# Altium schematic sheet 1166V30-4.SchDoc
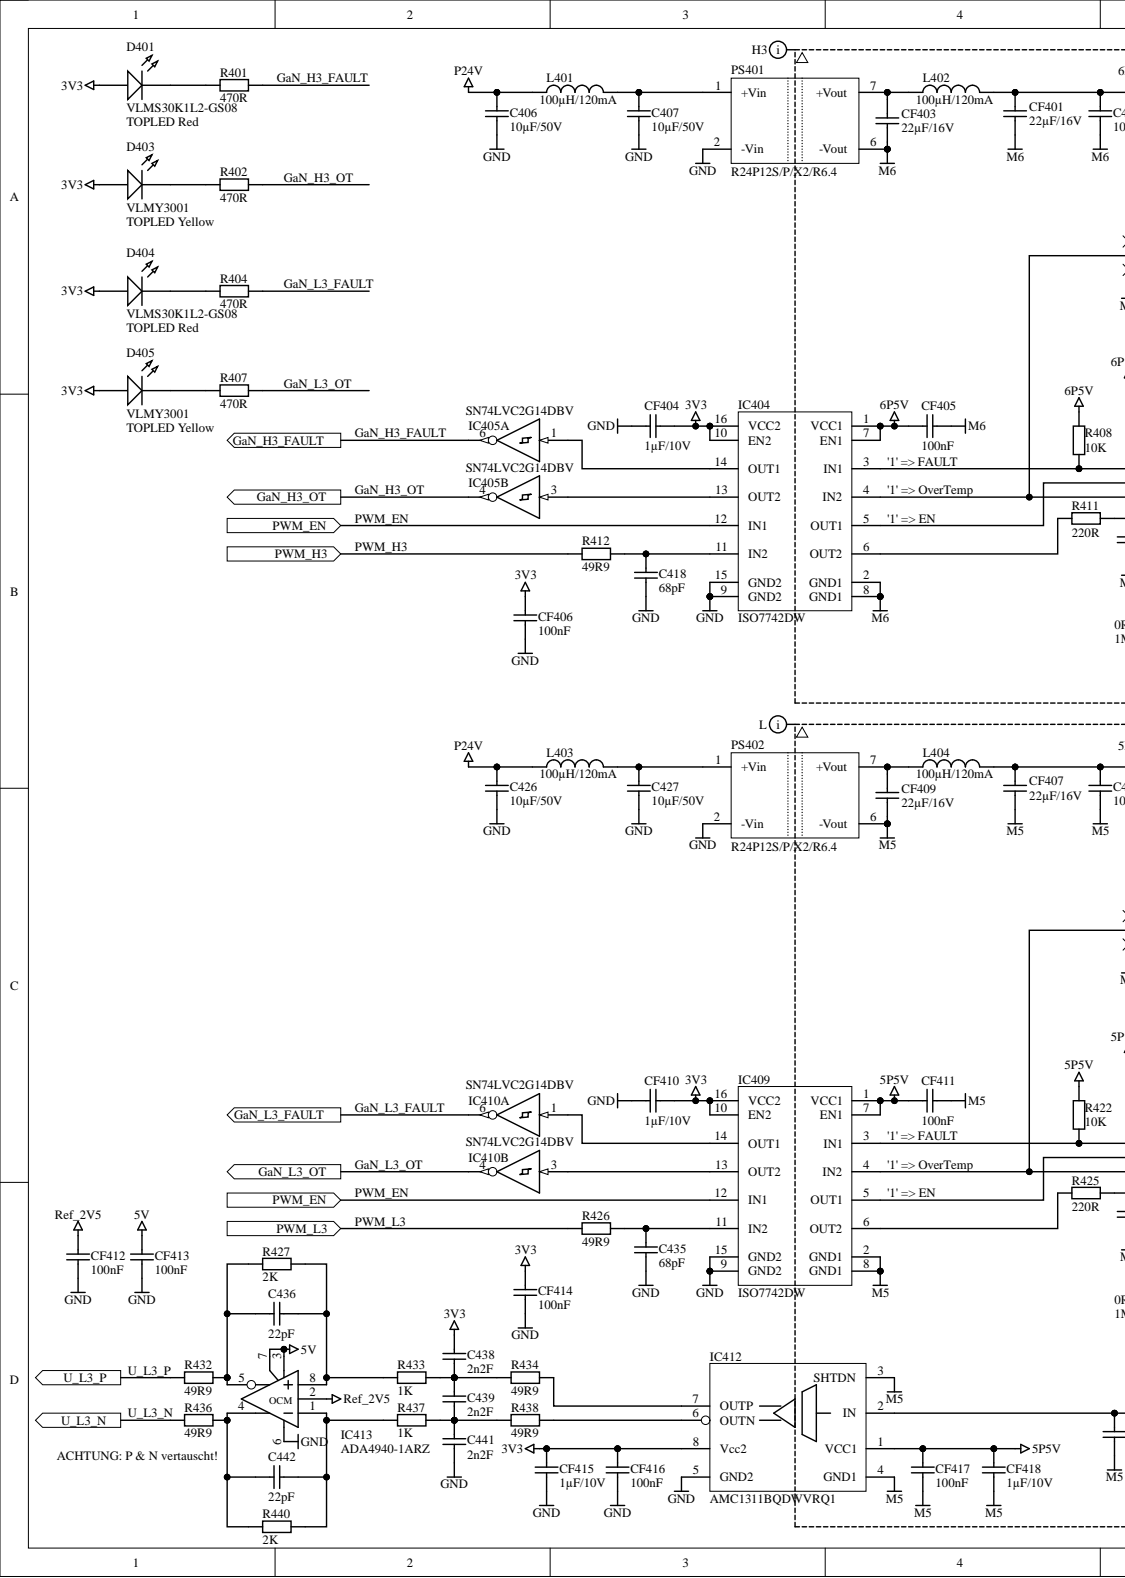
[diagram p0: 1166V30-4.SchDoc, left]
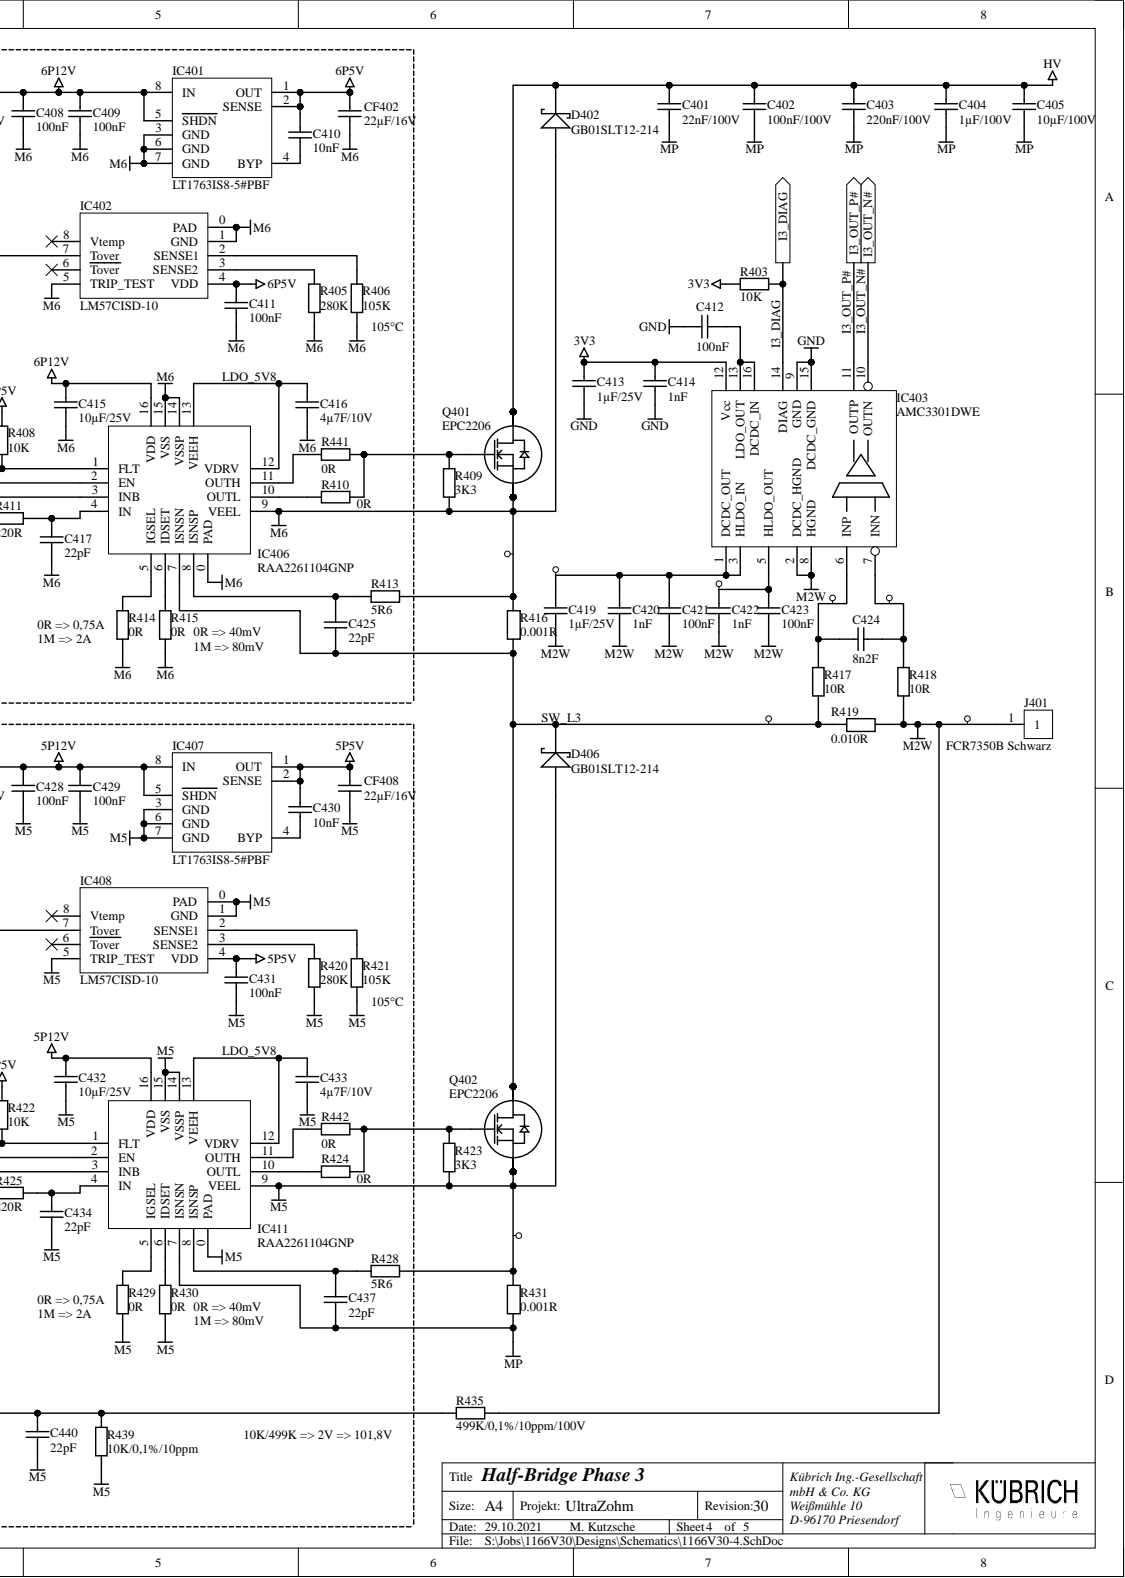
[diagram p1: 1166V30-4.SchDoc, right]

## Parts
C401  22nF/100V  [0805-Cap]
C402  100nF/100V  [0805-Cap]
C403  220nF/100V  [0805-Cap]
C404  1µF/100V  [0805-Cap]
C405  10µF/100V  [1210-Cap]
C406  10µF/50V  [1206-Cap]
C407  10µF/50V  [1206-Cap]
C408  100nF  [0603-Cap]
C409  100nF  [0603-Cap]
C410  10nF  [0603-Cap]
C411  100nF  [0603-Cap]
C412  100nF  [0603-Cap]
C413  1µF/25V  [1206-Cap]
C414  1nF  [1206-Cap]
C415  10µF/25V  [0805-Cap]
C416  4µ7F/10V  [0805-Cap]
C417  22pF  [0603-Cap]
C418  68pF  [0603-Cap]
C419  1µF/25V  [0603-Cap]
C420  1nF  [0603-Cap]
C421  100nF  [0603-Cap]
C422  1nF  [1206-Cap]
C423  100nF  [1206-Cap]
C424  8n2F  [0603-Cap]
C425  22pF  [0603-Cap]
C426  10µF/50V  [1206-Cap]
C427  10µF/50V  [1206-Cap]
C428  100nF  [0603-Cap]
C429  100nF  [0603-Cap]
C430  10nF  [0603-Cap]
C431  100nF  [0603-Cap]
C432  10µF/25V  [0805-Cap]
C433  4µ7F/10V  [0805-Cap]
C434  22pF  [0603-Cap]
C435  68pF  [0603-Cap]
C436  22pF  [0603-Cap]
C437  22pF  [0603-Cap]
C438  2n2F  [0603-Cap]
C439  2n2F  [0603-Cap]
C440  22pF  [0603-Cap]
C441  2n2F  [0603-Cap]
C442  22pF  [0603-Cap]
CF401  22µF/16V  [0805-Cap]
CF402  22µF/16V  [0805-Cap]
CF403  22µF/16V  [0805-Cap]
CF404  1µF/10V  [0603-Cap]
CF405  100nF  [0603-Cap]
CF406  100nF  [0603-Cap]
CF407  22µF/16V  [0805-Cap]
CF408  22µF/16V  [0805-Cap]
CF409  22µF/16V  [0805-Cap]
CF410  1µF/10V  [0603-Cap]
CF411  100nF  [0603-Cap]
CF412  100nF  [0603-Cap]
CF413  100nF  [0603-Cap]
CF414  100nF  [0603-Cap]
CF415  1µF/10V  [0603-Cap]
CF416  100nF  [0603-Cap]
CF417  100nF  [0603-Cap]
CF418  1µF/10V  [0603-Cap]
D401  VLMS30K1L2-GS08  [PLCC-2_rot]
D402  GB01SLT12-214  [DO-214 (SMB)]
D403  VLMY3001  Class II: TOPLED Yellow  [PLCC-2_gelb]
D404  VLMS30K1L2-GS08  Class II: TOPLED Red  [PLCC-2_rot]
D405  VLMY3001  Class II: TOPLED Yellow  [PLCC-2_gelb]
D406  GB01SLT12-214  [DO-214 (SMB)]
IC401  LT1763IS8-5#PBF  [SOIC-8-N]
IC402  LM57CISD-10  [WSON-8 (2.5x2.5mm)]
IC403  AMC3301DWE  [SOIC-16-W - 8.1mm]
IC404  ISO7742DW  [SOIC-16-W - 8.1mm]
IC405  SN74LVC2G14DBV  [SOT-23-6]  (parts A,B)
IC406  RAA2261104GNP  [QFN-16 (4x4mm)]
IC407  LT1763IS8-5#PBF  [SOIC-8-N]
IC408  LM57CISD-10  [WSON-8 (2.5x2.5mm)]
IC409  ISO7742DW  [SOIC-16-W - 8.1mm]
IC410  SN74LVC2G14DBV  [SOT-23-6]  (parts A,B)
IC411  RAA2261104GNP  [QFN-16 (4x4mm)]
IC412  AMC1311BQDWVRQ1  [SOIC-8-W]
IC413  ADA4940-1ARZ  [SOIC-8-N]
J401  FCR7350B Schwarz  [SLB4-I/90 - 4mm - Schwarz - CLIFF]
L401  100µH/120mA  [1007-IND (2518)]
L402  100µH/120mA  [1007-IND (2518)]
L403  100µH/120mA  [1007-IND (2518)]
L404  100µH/120mA  [1007-IND (2518)]
PS401  R24P12S/P/X2/R6.4  [SIP-7 Single - X2]
PS402  R24P12S/P/X2/R6.4  [SIP-7 Single - X2]
Q401  EPC2206  [D0232]
Q402  EPC2206  [D0232]
R401  470R  [0603-Res]
R402  470R  [0603-Res]
R403  10K  [0603-Res]
R404  470R  [0603-Res]
R405  280K  [0603-Res]
R406  105K  [0603-Res]
R407  470R  [0603-Res]
R408  10K  [0603-Res]
R409  3K3  [0603-Res]
R410  0R  [0603-Res]
R411  220R  [0603-Res]
R412  49R9  [0603-Res]
R413  5R6  [0603-Res]
R414  0R  [0603-Res]
R415  0R  [0603-Res]
R416  0.001R  [2512]
R417  10R  [0603-Res]
R418  10R  [0603-Res]
R419  0.010R  [GMR320]
R420  280K  [0603-Res]
R421  105K  [0603-Res]
R422  10K  [0603-Res]
R423  3K3  [0603-Res]
R424  0R  [0603-Res]
R425  220R  [0603-Res]
R426  49R9  [0603-Res]
R427  2K  [0603-Res]
R428  5R6  [0603-Res]
R429  0R  [0603-Res]
R430  0R  [0603-Res]
R431  0.001R  [2512]
R432  49R9  [0603-Res]
R433  1K  [0603-Res]
R434  49R9  [0603-Res]
R435  499K/0,1%/10ppm/100V  [0805-Res]
R436  49R9  [0603-Res]
R437  1K  [0603-Res]
R438  49R9  [0603-Res]
R439  10K/0,1%/10ppm  [0603-Res]
R440  2K  [0603-Res]
R441  0R  [0603-Res]
R442  0R  [0603-Res]

## Ports
GaN_H3_FAULT, GaN_H3_OT, GaN_L3_FAULT, GaN_L3_OT, I3_DIAG, I3_OUT_N#, I3_OUT_P#, PWM_EN, PWM_H3, PWM_L3, U_L3_N, U_L3_P

## Nets
3V3: C413.1 C414.1 C438.2 CF404.1 CF406.1 CF410.1 CF414.1 CF415.1 CF416.1 D401.1 D403.1 D404.1 D405.1 IC403.12(Vcc) IC404.10(EN2) IC404.16(VCC2) IC409.10(EN2) IC409.16(VCC2) IC412.8(Vcc2) R403.2
5P5V: C430.1 C431.1 CF408.1 CF411.2 CF417.1 CF418.1 IC407.1(OUT) IC407.2(SENSE) IC408.4(VDD) IC409.1(VCC1) IC409.7(EN1) IC412.1(VCC1) R422.1
5P12V: C428.1 C429.1 C432.2 CF407.1 IC407.5(SHDN) IC407.8(IN) IC411.16(VDD) L404.2
5V: CF413.1 IC413.3 IC413.7
6P5V: C410.1 C411.1 CF402.1 CF405.2 IC401.1(OUT) IC401.2(SENSE) IC402.4(VDD) IC404.1(VCC1) IC404.7(EN1) R408.1
6P12V: C408.1 C409.1 C415.2 CF401.1 IC401.5(SHDN) IC401.8(IN) IC406.16(VDD) L402.2
GND: C406.1 C407.1 C412.1 C413.2 C414.2 C418.1 C426.1 C427.1 C435.1 C441.1 CF404.2 CF406.2 CF410.2 CF412.2 CF413.2 CF414.2 CF415.2 CF416.2 IC403.9(GND) IC403.15(DCDC_GND) IC404.9(GND2) IC404.15(GND2) IC405.2 IC409.9(GND2) IC409.15(GND2) IC410.2 IC412.5(GND2) IC413.6 PS401.2(-Vin) PS402.2(-Vin)
HV: C401.1 C402.1 C403.1 C404.1 C405.1 D402.2 Q401.3 Q401.4 Q401.7 Q401.8 Q401.11 Q401.12 Q401.15 Q401.16 Q401.19 Q401.20 Q401.23 Q401.24 Q401.27 Q401.28
M2W: C419.2 C420.2 C421.2 C422.2 C423.2 IC403.2(DCDC_HGND) IC403.8(HGND) J401.1 R418.1 R419.1 R435.2
M5: C428.2 C429.2 C431.2 C432.1 C433.2 C434.1 C440.1 CF407.2 CF408.2 CF409.2 CF411.1 CF417.2 CF418.2 D406.1 IC407.3(GND) IC407.6(GND) IC407.7(GND) IC408.0(PAD) IC408.1(GND) IC408.5(TRIP_TEST) IC409.2(GND1) IC409.8(GND1) IC411.0(PAD) IC411.9(VEEL) IC411.14(VSSP) IC411.15(VSS) IC412.3(SHTDN) IC412.4(GND1) PS402.6(-Vout) Q402.2 Q402.5 Q402.6 Q402.9 Q402.10 Q402.13 Q402.14 Q402.17 Q402.18 Q402.21 Q402.22 Q402.25 Q402.26 Q402.29 Q402.30 R420.2 R421.2 R423.1 R428.2 R429.2 R430.2 R431.2 R439.2
M6: C408.2 C409.2 C411.2 C415.1 C416.2 C417.1 CF401.2 CF402.2 CF403.2 CF405.1 D402.1 IC401.3(GND) IC401.6(GND) IC401.7(GND) IC402.0(PAD) IC402.1(GND) IC402.5(TRIP_TEST) IC404.2(GND1) IC404.8(GND1) IC406.0(PAD) IC406.9(VEEL) IC406.14(VSSP) IC406.15(VSS) PS401.6(-Vout) Q401.2 Q401.5 Q401.6 Q401.9 Q401.10 Q401.13 Q401.14 Q401.17 Q401.18 Q401.21 Q401.22 Q401.25 Q401.26 Q401.29 Q401.30 R405.2 R406.2 R409.1 R413.2 R414.2 R415.2 R416.2
MP: C401.2 C402.2 C403.2 C404.2 C405.2 C437.1 IC411.7(ISNSN) R431.1
P24V: C406.2 C426.2 L401.1 L403.1
Ref_2V5: CF412.1 IC413.2(OCM)
VCC: IC405.5 IC410.5
GaN_H3_FAULT: IC405.6 R401.2 ; port GaN_H3_FAULT
GaN_H3_OT: IC405.4 R402.2 ; port GaN_H3_OT
GaN_L3_FAULT: IC410.6 R404.2 ; port GaN_L3_FAULT
GaN_L3_OT: IC410.4 R407.2 ; port GaN_L3_OT
I3_DIAG: IC403.14(DIAG) R403.1 ; port I3_DIAG
I3_OUT_N#: IC403.10(OUTN) ; port I3_OUT_N#
I3_OUT_P#: IC403.11(OUTP) ; port I3_OUT_P#
PWM_EN: IC404.12(IN1) IC409.12(IN1) ; port PWM_EN
PWM_H3: R412.2 ; port PWM_H3
PWM_L3: R426.2 ; port PWM_L3
SW_L3: C425.1 D406.2 IC406.7(ISNSN) Q402.3 Q402.4 Q402.7 Q402.8 Q402.11 Q402.12 Q402.15 Q402.16 Q402.19 Q402.20 Q402.23 Q402.24 Q402.27 Q402.28 R416.1 R417.1 R419.2
U_L3_N: R436.2 ; port U_L3_N
U_L3_P: R432.2 ; port U_L3_P
NetC407_2: C407.2 L401.2 PS401.1(+Vin)
NetC410_2: C410.2 IC401.4(BYP)
NetC412_2: C412.2 IC403.13(LDO_OUT) IC403.16(DCDC_IN)
NetC416_1: C416.1 IC406.12(VDRV) IC406.13(VEEH)
NetC417_2: C417.2 IC406.4(IN) R411.1
NetC418_2: C418.2 IC404.11(IN2) R412.1
NetC419_1: C419.1 C420.1 C421.1 IC403.1(DCDC_OUT) IC403.3(HLDO_IN)
NetC422_1: C422.1 C423.1 IC403.5(HLDO_OUT)
NetC424_1: C424.1 IC403.7(INN) R418.2
NetC424_2: C424.2 IC403.6(INP) R417.2
NetC425_2: C425.2 IC406.8(ISNSP) R413.1
NetC427_2: C427.2 L403.2 PS402.1(+Vin)
NetC430_2: C430.2 IC407.4(BYP)
NetC433_1: C433.1 IC411.12(VDRV) IC411.13(VEEH)
NetC434_2: C434.2 IC411.4(IN) R425.1
NetC435_2: C435.2 IC409.11(IN2) R426.1
NetC436_1: C436.1 IC413.8 R427.1 R433.2
NetC436_2: C436.2 IC413.5 R427.2 R432.1
NetC437_2: C437.2 IC411.8(ISNSP) R428.1
NetC438_1: C438.1 C439.2 R433.1 R434.2
NetC439_1: C439.1 C441.2 R437.1 R438.2
NetC440_2: C440.2 IC412.2(IN) R435.1 R439.1
NetC442_1: C442.1 IC413.1 R437.2 R440.1
NetC442_2: C442.2 IC413.4 R436.1 R440.2
NetCF403_1: CF403.1 L402.1 PS401.7(+Vout)
NetCF409_1: CF409.1 L404.1 PS402.7(+Vout)
NetD401_2: D401.2 R401.1
NetD403_2: D403.2 R402.1
NetD404_2: D404.2 R404.1
NetD405_2: D405.2 R407.1
NetIC402_2: IC402.2(SENSE1) R406.1
NetIC402_3: IC402.3(SENSE2) R405.1
NetIC402_7: IC402.7(Tover) IC404.4(IN2) IC406.3(INB)
NetIC404_3: IC404.3(IN1) IC406.1(FLT) R408.2
NetIC404_5: IC404.5(OUT1) IC406.2(EN)
NetIC404_6: IC404.6(OUT2) R411.2
NetIC404_13: IC404.13(OUT2) IC405.3
NetIC404_14: IC404.14(OUT1) IC405.1
NetIC406_5: IC406.5(IGSEL) R414.1
NetIC406_6: IC406.6(IDSET) R415.1
NetIC406_10: IC406.10(OUTL) R410.1
NetIC406_11: IC406.11(OUTH) R441.1
NetIC408_2: IC408.2(SENSE1) R421.1
NetIC408_3: IC408.3(SENSE2) R420.1
NetIC408_7: IC408.7(Tover) IC409.4(IN2) IC411.3(INB)
NetIC409_3: IC409.3(IN1) IC411.1(FLT) R422.2
NetIC409_5: IC409.5(OUT1) IC411.2(EN)
NetIC409_6: IC409.6(OUT2) R425.2
NetIC409_13: IC409.13(OUT2) IC410.3
NetIC409_14: IC409.14(OUT1) IC410.1
NetIC411_5: IC411.5(IGSEL) R429.1
NetIC411_6: IC411.6(IDSET) R430.1
NetIC411_10: IC411.10(OUTL) R424.1
NetIC411_11: IC411.11(OUTH) R442.1
NetIC412_6: IC412.6(OUTN) R438.1
NetIC412_7: IC412.7(OUTP) R434.1
NetQ401_1: Q401.1 R409.2 R410.2 R441.2
NetQ402_1: Q402.1 R423.2 R424.2 R442.2

Not connected: IC402 6(Tover) 8(Vtemp); IC408 6(Tover) 8(Vtemp)

## Library data of the parts
C401-C442 CF401-CF418 = Cap_SMD: Capacitor (SMD); Class I: Pasiv; Class II: Capacitor; Component Kind: Standard; Last edited: 20.11.2006 10:25; Library Name: KIng_RLC.IntLib
  C401: value: 22nF/100V
  C402: value: 100nF/100V
  C403: value: 220nF/100V
  C404: value: 1µF/100V
  C405: value: 10µF/100V
  C406 C407 C426 C427: value: 10µF/50V
  C408 C409 C411 C412 C421 C423 C428 C429 C431 CF405 CF406 CF411-CF414 CF416 CF417: value: 100nF
  C410 C430: value: 10nF
  C413 C419: value: 1µF/25V
  C414 C420 C422: value: 1nF
  C415 C432: value: 10µF/25V
  C416 C433: value: 4µ7F/10V
  C417 C425 C434 C436 C437 C440 C442: value: 22pF
  C418 C435: value: 68pF
  C424: value: 8n2F
  C438 C439 C441: value: 2n2F
  CF401-CF403 CF407-CF409: value: 22µF/16V
  CF404 CF410 CF415 CF418: value: 1µF/10V
D401 = VLMS30K1L2-GS08: Top LED Super red, 2mA, 18mcd, -40-100°C; Manufacturer: Vishay; Best.-Nr.: Farnell 132-8349; Class I: Discrete; Class II: TOPLED Red; Component Kind: Standard; Last edited: 23.01.2007 08:58; Library Name: KIng_Dioden.IntLib
D402 D406 = GB01SLT12-214: Silicon Carbide Schottky Diode Vr=1200V If=1A; Manufacturer: GeneSiC Semiconductor; Best.-Nr.: DigiKey: 1242-1169-1-ND; Class I: Discret; Package information: Unknown; Class II: Silicon Carbide Schottky Diode Vr=1200V If=1A; Component Kind: Standard; Datasheet: Ver. 7 Nov 2000; Package reference: DO-214AA (SMB); Library Name: KIng_Dioden.IntLib
D403 D405 = VLMY3001: Top LED Yellow, 2mA, 18mcd, -40-100°C; Manufacturer: Vishay; Best.-Nr.: ???; Class I: Discrete; Component Kind: Standard; Last edited: 23.01.2007 08:58; Library Name: KIng_Dioden.IntLib
D404 = VLMS30K1L2-GS08: Top LED Super red, 2mA, 18mcd, -40-100°C; Manufacturer: Vishay; Best.-Nr.: Farnell 132-8349; Class I: Discrete; Component Kind: Standard; Last edited: 23.01.2007 08:58; Library Name: KIng_Dioden.IntLib
IC401 IC407 = LT1763IS8-5#PBF: LDO 5V/500mA, Vin 20V (-40-125°C); Manufacturer: Linear Technology; Best.-Nr.: Farnell 229-5214; Class I: Discret; Package information: Unknown; Class II: LDO 5V/500mA, Vin 20V (-40-125°C); Component Kind: Standard; Datasheet: ???; Package reference: SO-8; Library Name: KIng_Voltage Regulator.IntLib
IC402 IC408 = LM57CISD-10: Temperature Sensor/Switch -40 - 150°C, Resistor-Programmable, 10°C Hysterese; Manufacturer: Texas Instruments; Best.-Nr.: DigiKey: LM57CISD-10/NOPBCT-ND; Class I: Sensor; Class II: Temperature Sensor/Switch -40 - 150°C, Resistor-Programmable, 10°C Hysterese; Component Kind: Standard; Library Name: King_Sensor.IntLib
IC403 = AMC3301DWE: ±250 mV Input Voltage Range, Reinforced Isolated Amplifier and High Bandwidth of 300 kHz; Manufacturer: Texas Instruments; Best.-Nr.: DigiKey: 296-AMC3301DWE-ND; Class II: ±250 mV, 300KHz, -40-125°C; Package information: Unknown; Class I: Isolated Amplifier; Component Kind: Standard; Datasheet: Unknown; Package reference: SOIC-16-W; Library Name: KIng_Opto-Coupler.IntLib
IC404 IC409 = ISO7742DW: Quad-CH 100Mbps Isolators 2 IN/2 OUT, 5000V Reinforced, (-40-125°C); Manufacturer: Texas Instruments; Best.-Nr.: DigiKey: 296-44609-1-ND; Class I: Optocoupler; Package information: Unknown; Class II: Quad-CH 100Mbps Isolators 2 IN/2 OUT, 5000V Reinforced, (-40-125°C); Component Kind: Standard; Datasheet: Unknown; Package reference: SOIC-16W; Library Name: KIng_Opto-Coupler.IntLib
IC405 IC410 = SN74LVC2G14D_: Dual Inverter with Schmitt Trigger Input; Manufacturer: Texas Instrument; Class I: Logic; value121: SN74LVC2G14DCK; Class II: Dual Inverter with Schmitt Trigger Input; Component Kind: Standard; Datasheet: SCES200J; Last edited: 22.01.2007 11:08; value111: SN74LVC2G14DBV; Library Name: KIng_Logik.IntLib
IC406 IC411 = RAA2261104GNP: Low -Side GaN FET-Driver, 6,5V - 18V; Manufacturer: Renesas; Best.-Nr.: Mouser: 968-2261104GNP#AA0; Class I: Discret; Package information: Unknown; Class II: Low -Side GaN FET-Driver, 6,5V - 18V; Component Kind: Standard; Datasheet: Rev. Mar 2002; Package reference: QFN-16; Library Name: KIng_MOSFET.IntLib
IC412 = AMC1311BQDWVRQ1: 2V Input, Automotive High-Impedance, Reinforced Isolated Amplifier for Voltage Sensing; Manufacturer: Texas Instruments; Best.-Nr.: Mouser: 595-AMC1311BQDWVRQ1; Class II: 2V, 275KHz, -40-125°C; Package information: Unknown; Class I: Isolated Amplifier; Component Kind: Standard; Datasheet: Unknown; Package reference: SOIC-8-W; Library Name: KIng_Opto-Coupler.IntLib
IC413 = ADA4940-1ARZ: Fully Differential ADC Drivers (-40-125°C); Manufacturer: ANALOG DEVICES; Best.-Nr.: Farnell 209-6178; Class I: Analog; Class II: Fully Differential ADC Drivers (-40-125°C); Component Kind: Standard; Datasheet: DSO11449, Ver. Aug 2000; Last edited: 04.01.2007 10:14; Package Reference: SOIC-N-8; Library Name: KIng_Analog-IC.IntLib
J401 = FCR7350B Schwarz: Labor Winkelbuchsen 4mm Schwarz; Manufacturer: CLIFF Electronic; Best.-Nr.: Farnell: 185-4507; Class I: Connectors; Class II: Labor Winkelbuchsen 4mm Schwarz; Component Kind: Standard; Last edited: 07.03.2007 13:28; Library Name: KIng_Connector.IntLib
L401-L404 = CB2518T101K: 100µH, 60/120mA. (1007), -40-105°C; Manufacturer: Taiyo Yuden; Best.-Nr.: DigiKey:   587-2192-1-ND; Class I: Pasive; Class II: 100µH, 60/120mA. (1007), -40-105°C; Component Kind: Standard; Last edited: 08.12.2006 12:28; Library Name: KIng_RLC.IntLib
PS401 PS402 = R24P12S/P/X2/R6.4: DC/DC Converter 24V to 12V, 1W, 84mA (unregulated) 6,4KVDC Rainforced; Manufacturer: Recom; Best.-Nr.: Mouser: 919-R24P12SX2PR6.4; Class I: Analog; Package information: Unknown; Class II: DC/DC Converter 24V to 12V, 1W, 84mA (unregulated) 6,4KVDC Rainforced; Component Kind: Standard; Datasheet: Rev. 28 Apr 2002; Library Name: KIng_DCDC-Converter.IntLib; Package reference: SIP-7
Q401 Q402 = EPC2206: GaN-Fet 80V, 2.2mOhm, 90A; Manufacturer: EPC; Best.-Nr.: DigiKey: 917-1212-1-ND; Class I: Discret; Package information: Unknown; Class II: GaN-Fet 80V, 2.2mOhm, 90A; Component Kind: Standard; Datasheet: Rev. Mar 2002; Package reference: DIE; Library Name: KIng_MOSFET.IntLib
R401-R415 R417 R418 R420-R430 R432-R442 = Res_SMD: Resistor SMD; Class I: Pasiv; Class II: Resistor; Component Kind: Standard; Last edited: 17.11.2006 11:38; Library Name: KIng_RLC.IntLib
  R401 R402 R404 R407: value: 470R
  R403 R408 R422: value: 10K
  R405 R420: value: 280K
  R406 R421: value: 105K
  R409 R423: value: 3K3
  R410 R414 R415 R424 R429 R430 R441 R442: value: 0R
  R411 R425: value: 220R
  R412 R426 R432 R434 R436 R438: value: 49R9
  R413 R428: value: 5R6
  R417 R418: value: 10R
  R427 R440: value: 2K
  R433 R437: value: 1K
  R435: value: 499K/0,1%/10ppm/100V
  R439: value: 10K/0,1%/10ppm
R416 R431 = CSS2H-2512R-1L00F: Shunt 0.001R, 5W, 1%, 50ppm/°C; Manufacturer: Bourns; Best.-Nr.: Farnell 257-6347; Class I: Pasiv; Class II: Shunt 5W; Component Kind: Standard; Last edited: 23.11.2010 14:12; value: 0.001R; Library Name: KIng_Shunt.IntLib
R419 = GMR320HJBFA10L0: Shunt 0.010R, 10W, 1%, 25ppm; Manufacturer: ROHM; Best.-Nr.: DigiKey 511-GMR320HJBFA10L0CT-ND; Mouser: 755-GMR320HJBFA10L0; Class I: Pasiv; Class II: Shunt 10W; Component Kind: Standard; Last edited: 23.11.2006 14:12; value: 0.010R; Library Name: KIng_Shunt.IntLib
